# Altium schematic sheet IF-HBL_sch.SchDoc
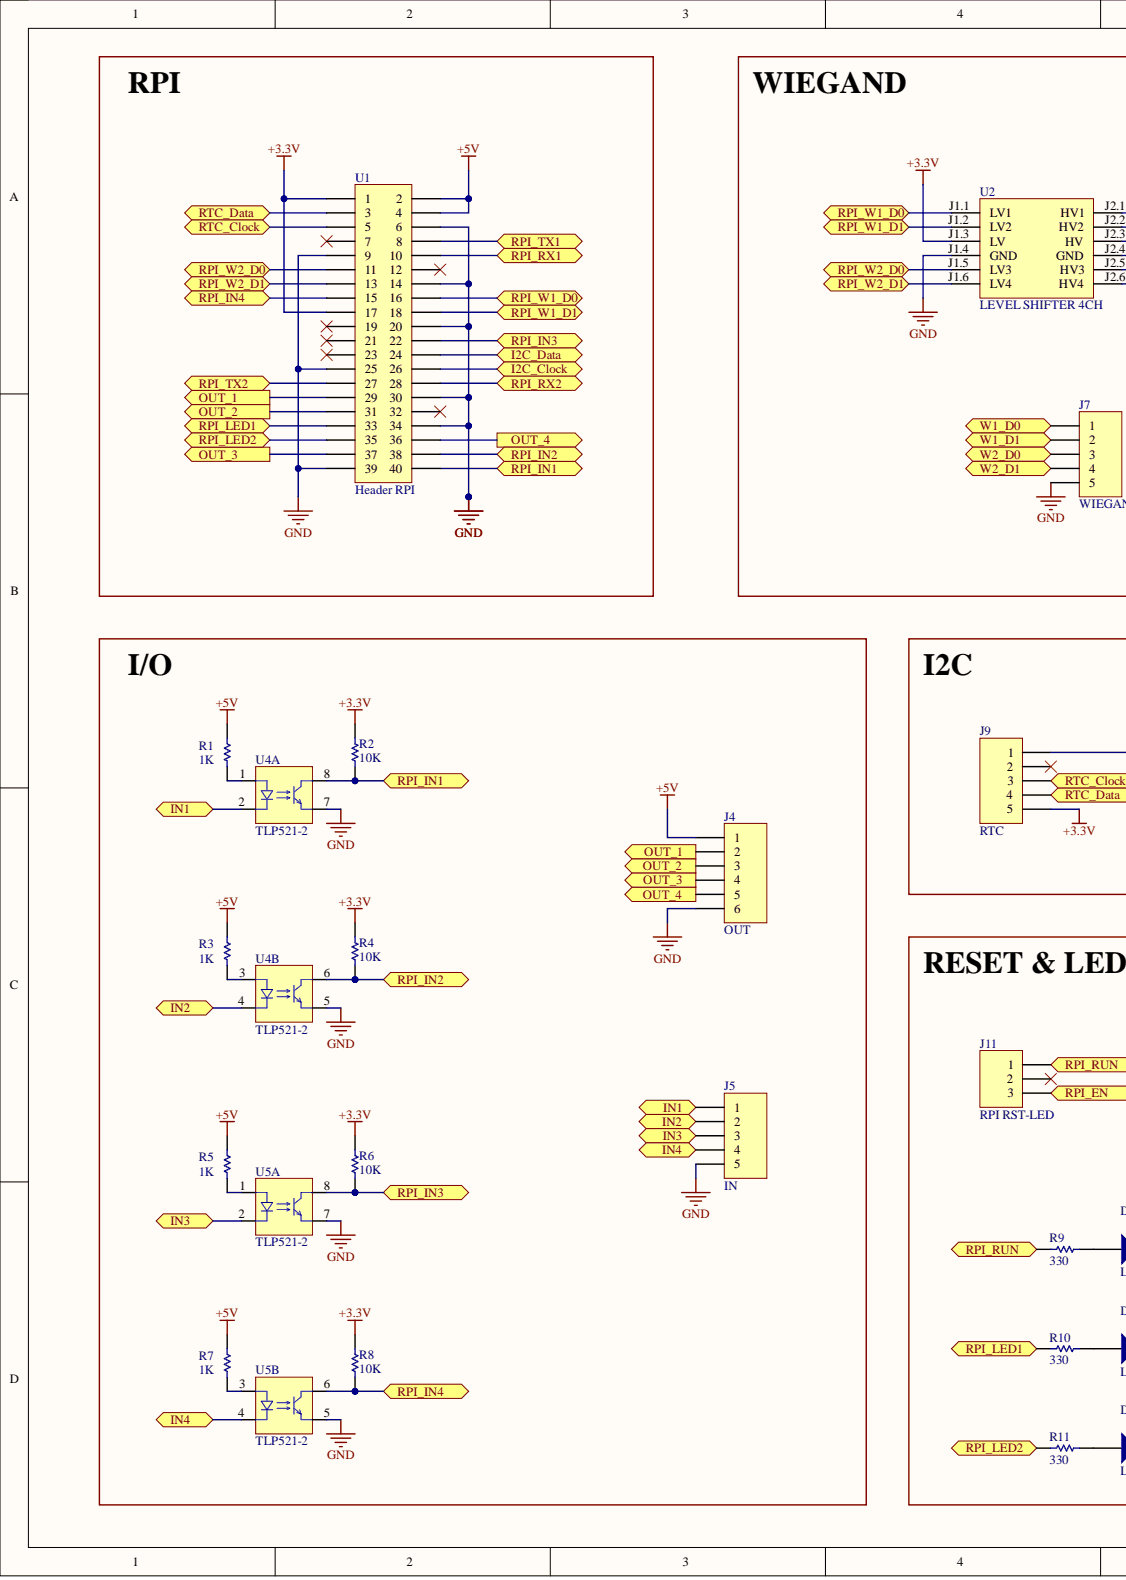
[diagram p0: IF-HBL_sch.SchDoc, left]
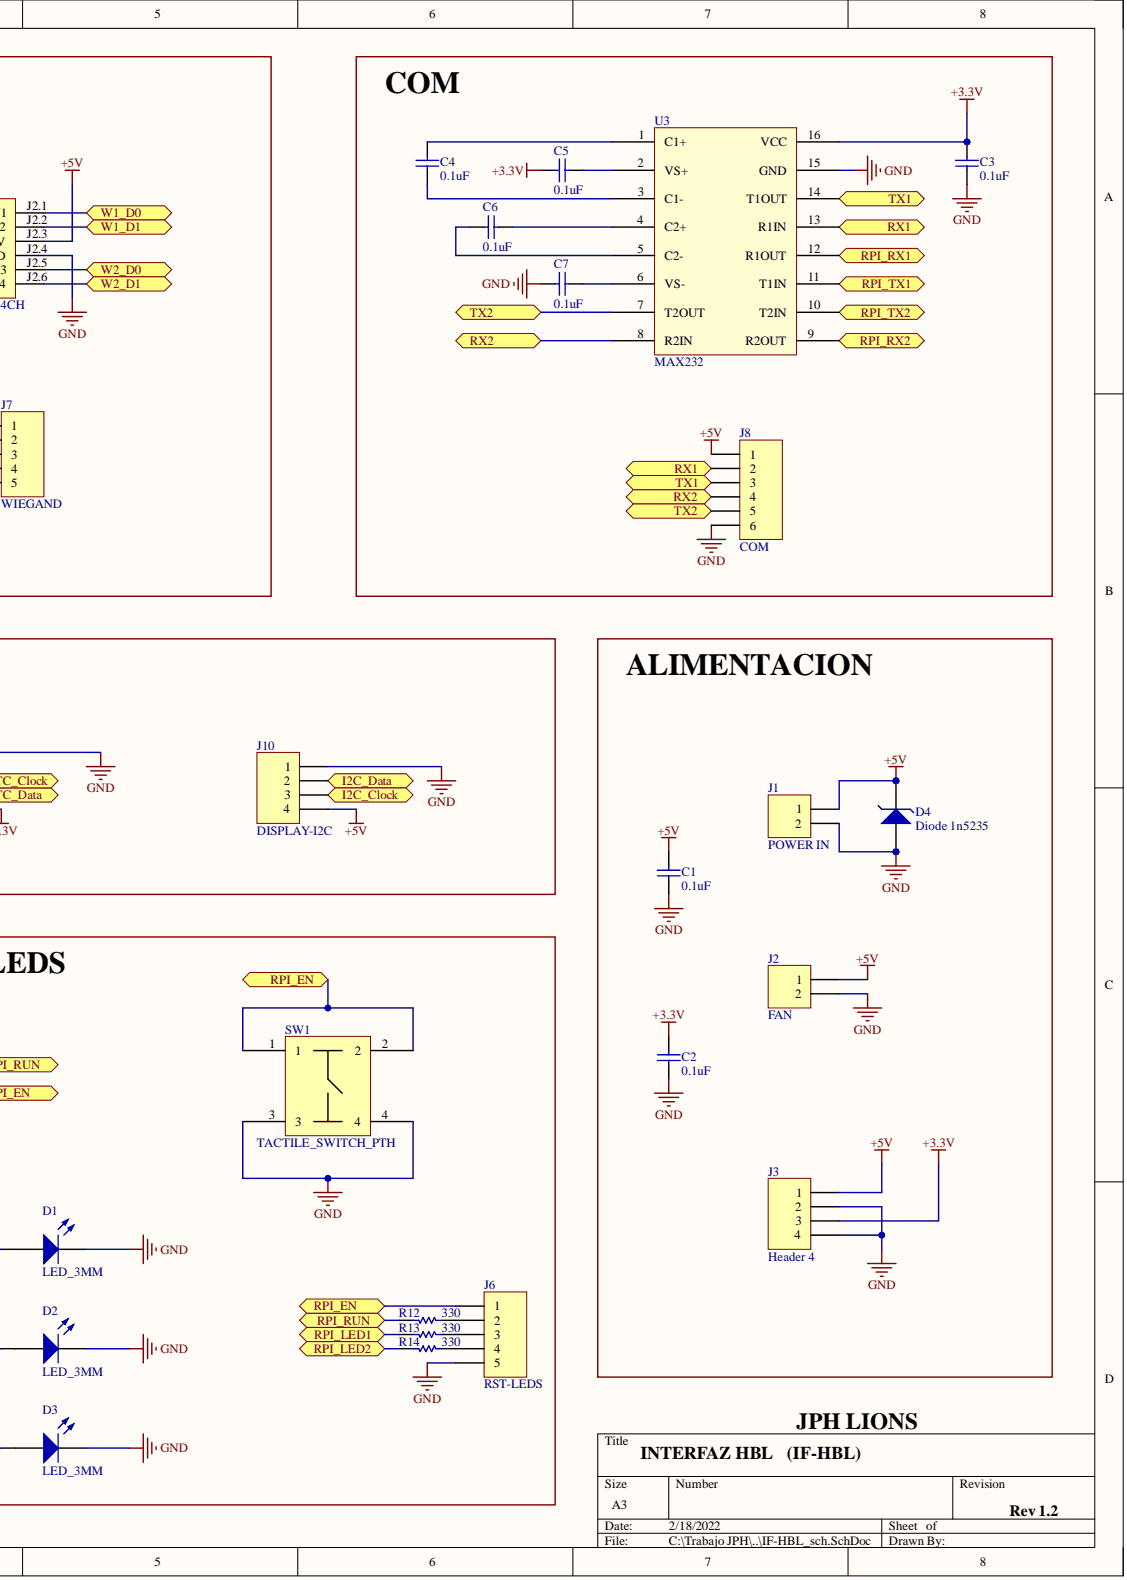
[diagram p1: IF-HBL_sch.SchDoc, right]

## Parts
C1  value 0.1uF  [CAP CER 2.54mm]  (hidden comment: CAP CER)
C2  value 0.1uF  [CAP CER 2.54mm]  (hidden comment: CAP CER)
C3  value 0.1uF  [CAP CER 2.54mm]  (hidden comment: CAP CER)
C4  value 0.1uF  [CAP CER 2.54mm]  (hidden comment: CAP CER)
C5  value 0.1uF  [CAP CER 2.54mm]  (hidden comment: CAP CER)
C6  value 0.1uF  [CAP CER 2.54mm]  (hidden comment: CAP CER)
C7  value 0.1uF  [CAP CER 2.54mm]  (hidden comment: CAP CER)
D1  LED_3MM  [LED_3MM_RED]
D2  LED_3MM  [LED_3MM_RED]
D3  LED_3MM  [LED_3MM_RED]
D4  Diode 1n5235  [1N5235]
J1  POWER IN  [JST-B2B-XH]
J2  FAN  [HEADERx2]
J3  Header 4  [HEADERx4]
J4  OUT  [JST-B6B-XH]
J5  IN  [JST-B5B-XH]
J6  RST-LEDS  [JST-B5B-XH]
J7  WIEGAND  [JST-B5B-XH]
J8  COM  [JST-B6B-XH]
J9  RTC  [RTC DS3231]
J10  DISPLAY-I2C  [JST-B4B-XH]
J11  RPI RST-LED  [JST-B3B-XH]
R1  value 1K  [RES_1/4_300mils]  (hidden comment: RES_1/4 WATT)
R2  value 10K  [RES_1/4_300mils]  (hidden comment: RES_1/4 WATT)
R3  value 1K  [RES_1/4_300mils]  (hidden comment: RES_1/4 WATT)
R4  value 10K  [RES_1/4_300mils]  (hidden comment: RES_1/4 WATT)
R5  value 1K  [RES_1/4_300mils]  (hidden comment: RES_1/4 WATT)
R6  value 10K  [RES_1/4_300mils]  (hidden comment: RES_1/4 WATT)
R7  value 1K  [RES_1/4_300mils]  (hidden comment: RES_1/4 WATT)
R8  value 10K  [RES_1/4_300mils]  (hidden comment: RES_1/4 WATT)
R9  value 330  [RES_1/4_300mils]  (hidden comment: RES_1/4 WATT)
R10  value 330  [RES_1/4_300mils]  (hidden comment: RES_1/4 WATT)
R11  value 330  [RES_1/4_300mils]  (hidden comment: RES_1/4 WATT)
R12  value 330  [RES_1/4_300mils]  (hidden comment: RES_1/4 WATT)
R13  value 330  [RES_1/4_300mils]  (hidden comment: RES_1/4 WATT)
R14  value 330  [RES_1/4_300mils]  (hidden comment: RES_1/4 WATT)
SW1  TACTILE_SWITCH_PTH  [TACTILE_SWITCH_PTH]
U1  Header RPI  [HEADER_20x2]
U2  LEVEL SHIFTER 4CH  [LEVEL SHIFTER 4CH]
U3  MAX232  [SO16_N]
U4  TLP521-2  [DIP8]  (parts A,B)
U5  TLP521-2  [DIP8]  (parts A,B)

## Ports
I2C_Clock, I2C_Data, IN1, IN2, IN3, IN4, OUT_1, OUT_2, OUT_3, OUT_4, RPI_EN, RPI_IN1, RPI_IN2, RPI_IN3, RPI_IN4, RPI_LED1, RPI_LED2, RPI_RUN, RPI_RX1, RPI_RX2, RPI_TX1, RPI_TX2, RPI_W1_D0, RPI_W1_D1, RPI_W2_D0, RPI_W2_D1, RTC_Clock, RTC_Data, RX1, RX2, TX1, TX2, W1_D0, W1_D1, W2_D0, W2_D1

## Nets
+3.3V: C2.2 C3.2 C5.1 J3.3 J9.5 R2.1 R4.1 R6.1 R8.1 U1.1 U1.17 U2.J1.3(LV) U3.16(VCC)
+5V: C1.2 D4.2 J1.1 J2.1 J3.1 J4.1 J8.1 J10.4 R1.2 R3.2 R5.2 R7.2 U1.2 U1.4 U2.J2.3(HV)
GND: C1.1 C2.1 C3.1 C7.1 D1.2 D2.2 D3.2 D4.1 J1.2 J2.2 J3.2 J3.4 J4.6 J5.5 J6.5 J7.5 J8.6 J9.1 J10.1 SW1.3 SW1.4 U1.6 U1.9 U1.14 U1.20 U1.25 U1.30 U1.34 U1.39 U2.J1.4(GND) U2.J2.4(GND) U3.15(GND) U4.5 U4.7 U5.5 U5.7
I2C_Clock: J10.3 U1.26 ; port I2C_Clock
I2C_Data: J10.2 U1.24 ; port I2C_Data
IN1: J5.1 U4.2 ; port IN1
IN2: J5.2 U4.4 ; port IN2
IN3: J5.3 U5.2 ; port IN3
IN4: J5.4 U5.4 ; port IN4
OUT_1: J4.2 U1.29 ; port OUT_1
OUT_2: J4.3 U1.31 ; port OUT_2
OUT_3: J4.4 U1.37 ; port OUT_3
OUT_4: J4.5 U1.36 ; port OUT_4
RPI_EN: J6.1 J11.3 SW1.1 SW1.2 ; port RPI_EN
RPI_IN1: R2.2 U1.40 U4.8 ; port RPI_IN1
RPI_IN2: R4.2 U1.38 U4.6 ; port RPI_IN2
RPI_IN3: R6.2 U1.22 U5.8 ; port RPI_IN3
RPI_IN4: R8.2 U1.15 U5.6 ; port RPI_IN4
RPI_LED1: R10.2 R13.2 U1.33 ; port RPI_LED1
RPI_LED2: R11.2 R14.1 U1.35 ; port RPI_LED2
RPI_RUN: J11.1 R9.2 R12.2 ; port RPI_RUN
RPI_RX1: U1.10 U3.12(R1OUT) ; port RPI_RX1
RPI_RX2: U1.28 U3.9(R2OUT) ; port RPI_RX2
RPI_TX1: U1.8 U3.11(T1IN) ; port RPI_TX1
RPI_TX2: U1.27 U3.10(T2IN) ; port RPI_TX2
RPI_W1_D0: U1.16 U2.J1.1(LV1) ; port RPI_W1_D0
RPI_W1_D1: U1.18 U2.J1.2(LV2) ; port RPI_W1_D1
RPI_W2_D0: U1.11 U2.J1.5(LV3) ; port RPI_W2_D0
RPI_W2_D1: U1.13 U2.J1.6(LV4) ; port RPI_W2_D1
RTC_Clock: J9.3 U1.5 ; port RTC_Clock
RTC_Data: J9.4 U1.3 ; port RTC_Data
RX1: J8.2 U3.13(R1IN) ; port RX1
RX2: J8.4 U3.8(R2IN) ; port RX2
TX1: J8.3 U3.14(T1OUT) ; port TX1
TX2: J8.5 U3.7(T2OUT) ; port TX2
W1_D0: J7.1 U2.J2.1(HV1) ; port W1_D0
W1_D1: J7.2 U2.J2.2(HV2) ; port W1_D1
W2_D0: J7.3 U2.J2.5(HV3) ; port W2_D0
W2_D1: J7.4 U2.J2.6(HV4) ; port W2_D1
NetC4_1: C4.1 U3.3(C1-)
NetC4_2: C4.2 U3.1(C1+)
NetC5_2: C5.2 U3.2(VS+)
NetC6_1: C6.1 U3.5(C2-)
NetC6_2: C6.2 U3.4(C2+)
NetC7_2: C7.2 U3.6(VS-)
NetD1_1: D1.1 R9.1
NetD2_1: D2.1 R10.1
NetD3_1: D3.1 R11.1
NetJ6_2: J6.2 R12.1
NetJ6_3: J6.3 R13.1
NetJ6_4: J6.4 R14.2
NetR1_1: R1.1 U4.1
NetR3_1: R3.1 U4.3
NetR5_1: R5.1 U5.1
NetR7_1: R7.1 U5.3

Not connected: J9 2; J11 2; U1 7 12 19 21 23 32

## Library data of the parts
C1-C7 = CAP CER 2.54mm: CAP CERAMICO PTH 2.54mm; Component Kind: Standard; Library Name: IF-HBL_rev1.2.SchLib
D4 = Diode 1n5235: Diode Zener 1n5235; Code_JEDEC: DO-204-AL; Component Kind: Standard; ComponentLink1Description: Manufacturer Link; ComponentLink1URL: http://www.fairchildsemi.com/; ComponentLink2Description: Datasheet; ComponentLink2URL: http://www.fairchildsemi.com/ds/1N%2F1N4001.pdf; DatasheetVersion: 1998; LatestRevisionDate: 17-Jul-2002; LatestRevisionNote: Re-released for DXP Platform.; PackageReference: DO-41; PackageVersion: Aug-1999; Published: 8-Jun-2000; Library Name: IF-HBL_rev1.2.SchLib; Publisher: Altium Limited
J1 = CONN_2_JST: Connector JST 2pin; Component Kind: Standard; LatestRevisionDate: 17-Jul-2002; LatestRevisionNote: Re-released for DXP Platform.; Library Name: IF-HBL_rev1.2.SchLib; Publisher: Altium Limited
J2 = HEADERx2: Header male pin paso 2.54mm x2; Component Kind: Standard; LatestRevisionDate: 17-Jul-2002; LatestRevisionNote: Re-released for DXP Platform.; Library Name: IF-HBL_rev1.2.SchLib; Publisher: Altium Limited
J3 = HEADERx4: Header male pin paso 2.54mm x4; Component Kind: Standard; LatestRevisionDate: 17-Jul-2002; LatestRevisionNote: Re-released for DXP Platform.; Library Name: IF-HBL_rev1.2.SchLib; Publisher: Altium Limited
J4 = CONN_6_JST: Connector Header 6pin; Component Kind: Standard; LatestRevisionDate: 17-Jul-2002; LatestRevisionNote: Re-released for DXP Platform.; Library Name: IF-HBL_rev1.2.SchLib; Publisher: Altium Limited
J5-J7 = CONN_5_JST: Connector header 5pin; Component Kind: Standard; LatestRevisionDate: 17-Jul-2002; LatestRevisionNote: Re-released for DXP Platform.; Library Name: IF-HBL_rev1.2.SchLib; Publisher: Altium Limited
J8 = CONN_6_JST: Connector JST 6pin; Component Kind: Standard; LatestRevisionDate: 17-Jul-2002; LatestRevisionNote: Re-released for DXP Platform.; Library Name: IF-HBL_rev1.2.SchLib; Publisher: Altium Limited
J9 = RTC DS3231: RTC DS3231 5pin; Component Kind: Standard; LatestRevisionDate: 17-Jul-2002; LatestRevisionNote: Re-released for DXP Platform.; Library Name: IF-HBL_rev1.2.SchLib; Publisher: Altium Limited
J10 = CONN_4_JST: Connector JST 4pin; Component Kind: Standard; LatestRevisionDate: 17-Jul-2002; LatestRevisionNote: Re-released for DXP Platform.; Library Name: IF-HBL_rev1.2.SchLib; Publisher: Altium Limited
J11 = CONN_3_JST: Connector JST 3pin; Component Kind: Standard; LatestRevisionDate: 17-Jul-2002; LatestRevisionNote: Re-released for DXP Platform.; Library Name: IF-HBL_rev1.2.SchLib; Publisher: Altium Limited
SW1 = TACTILE_SWITCH: Component Kind: Standard; Description: TACTILE_SWITCH_PTH; Library Name: IF-HBL_rev1.2.SchLib
U1 = Header 20X2: Header, 20-Pin, Dual row; Component Kind: Standard; LatestRevisionDate: 17-Jul-2002; LatestRevisionNote: Re-released for DXP Platform.; Library Name: IF-HBL_rev1.2.SchLib; Publisher: Altium Limited
U3 = MAX232_SMD: SMD Dual EIA-232 Drivers/Receivers; Component Kind: Standard; Library Name: IF-HBL_rev1.2.SchLib
U4 U5 = TLP521-2: Optocoupler, Phototransistor Output, Dual Channel, TTL Compatible, Pb-free; Component Kind: Standard; PackageReference: DIP8; Library Name: IF-HBL_rev1.2.SchLib
D1-D3 = LED_3MM: Component Kind: Standard; Description: LED_3MM; LatestRevisionDate: 08-Jul-2005; LatestRevisionNote: Mech Layer 1 removed.; PackageReference: LED-0; Published: 8-Jun-2000; Library Name: IF-HBL_rev1.2.SchLib; Publisher: Altium Limited
R1-R14 = RES_1/4 WATT: Component Kind: Standard; Description: RES_1/4 WATT; Library Name: IF-HBL_rev1.2.SchLib
U2 = LEVEL SHIFTER 4CH: Component Kind: Standard; Description: LEVEL SHIFTER 4CH; LatestRevisionDate: 17-Jul-2002; LatestRevisionNote: Re-released for DXP Platform.; Library Name: IF-HBL_rev1.2.SchLib; Publisher: Altium Limited
